# Altium schematic sheet MLDR187B_2.SchDoc
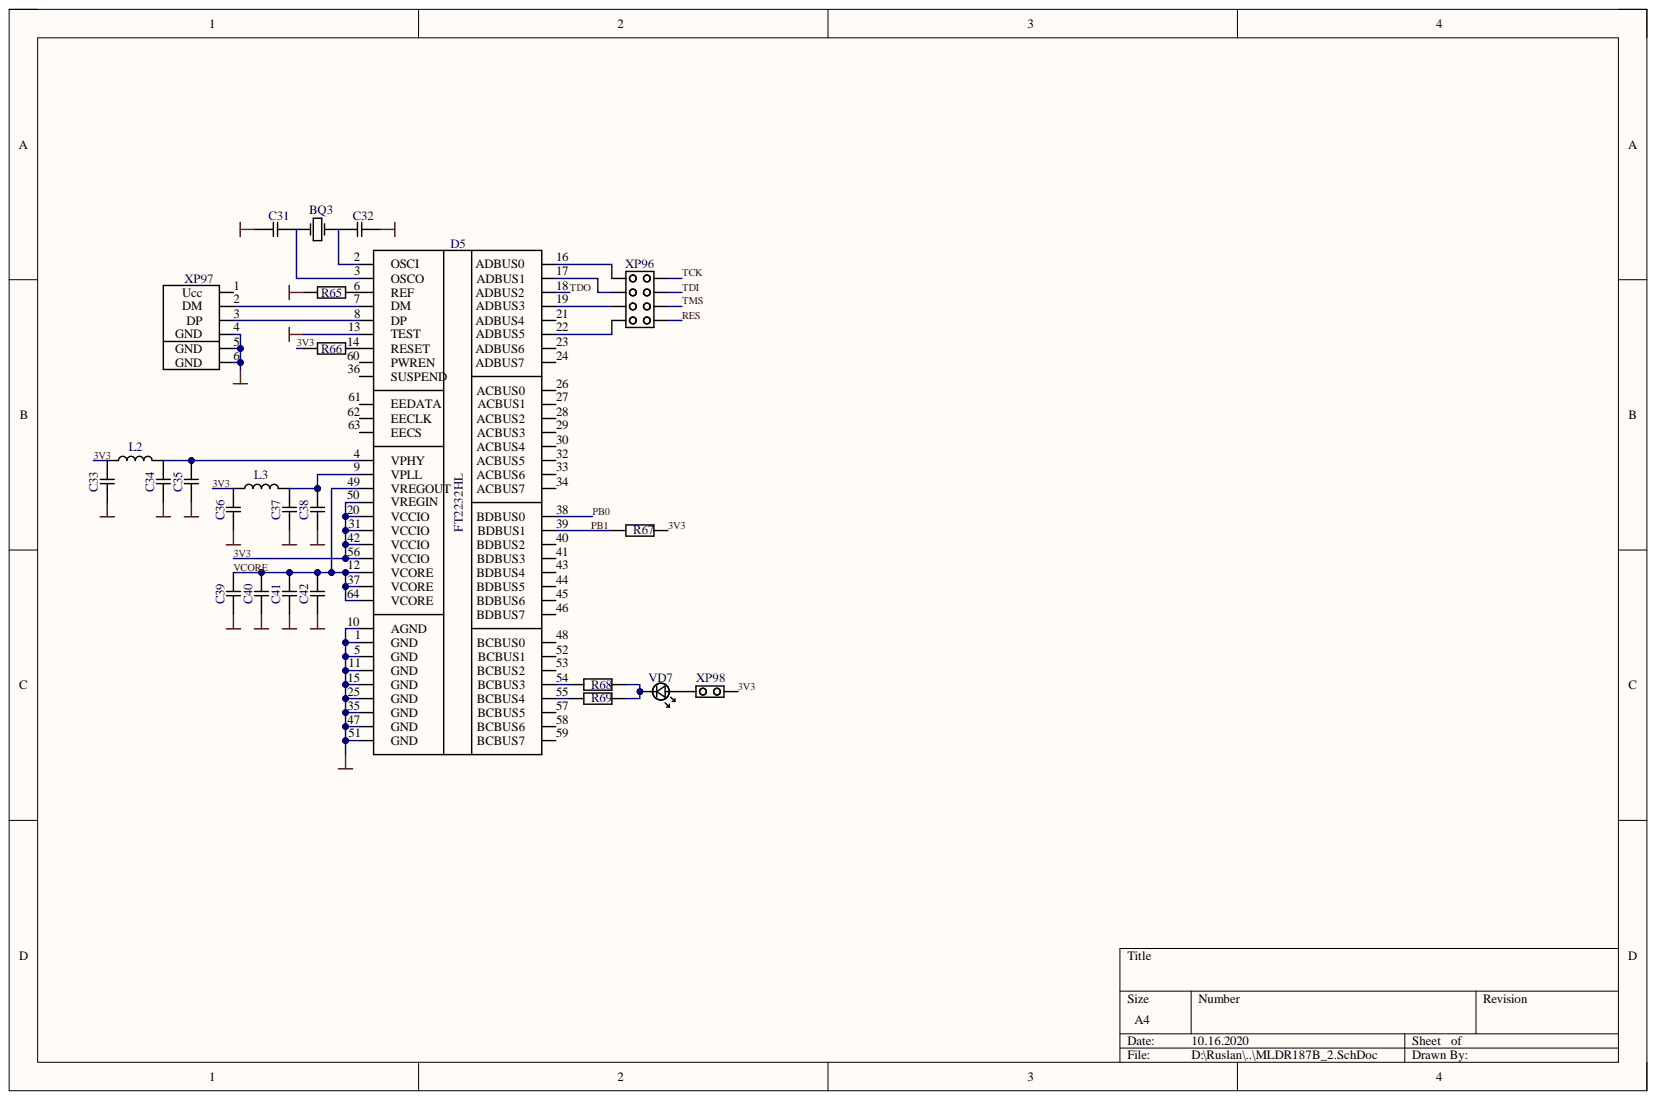
[diagram p0: MLDR187B_2.SchDoc]

## Parts
BQ3  KX-KT 12.0Mhz  [SMU-4]  (value not drawn)
C31  15pF 0603 NP0  [0603]  (value not drawn)
C32  15pF 0603 NP0  [0603]  (value not drawn)
C33  0.1uF 0603 X7R  [0603]  (value not drawn)
C34  0.1uF 0603 X7R  [0603]  (value not drawn)
C35  100pF 0603 NP0  [0603]  (value not drawn)
C36  0.1uF 0603 X7R  [0603]  (value not drawn)
C37  0.1uF 0603 X7R  [0603]  (value not drawn)
C38  100pF 0603 NP0  [0603]  (value not drawn)
C39  0.1uF 0603 X7R  [0603]  (value not drawn)
C40  0.1uF 0603 X7R  [0603]  (value not drawn)
C41  0.1uF 0603 X7R  [0603]  (value not drawn)
C42  0.1uF 0603 X7R  [0603]  (value not drawn)
D5  FT2232HL  [LQFP-64]
L2  BLM18HE102SN1D  [0603]  (value not drawn)
L3  BLM18HE102SN1D  [0603]  (value not drawn)
R65  12k 0603 1%  [0603]  (value not drawn)
R66  33k 0603 5%  [0603]  (value not drawn)
R67  33k 0603 5%  [0603]  (value not drawn)
R68  680Ohm 0603 5%  [0603]  (value not drawn)
R69  680Ohm 0603 5%  [0603]  (value not drawn)
VD7  LED 1206  [VD1206]  (value not drawn)
XP96  PLD-8  [PLD-8]  (value not drawn)
XP97  USBB  [USB_B]  (value not drawn)
XP98  PLS-2  [PLS-2]  (value not drawn)

## Nets
GND: C31.2 C32.1 C33.1 C34.1 C35.1 C36.1 C37.1 C38.1 C39.1 C40.1 C41.1 C42.1 D5.1(GND) D5.5(GND) D5.10(AGND) D5.11(GND) D5.13(TEST) D5.15(GND) D5.25(GND) D5.35(GND) D5.47(GND) D5.51(GND) R65.1 XP97.4(GND) XP97.5(GND) XP97.6(GND)
3V3: C33.2 C36.2 D5.20(VCCIO) D5.31(VCCIO) D5.42(VCCIO) D5.50(VREGIN) D5.56(VCCIO) L2.1 L3.1 R66.1 R67.1 XP98.2
PB0: D5.38(BDBUS0)
PB1: D5.39(BDBUS1) R67.2
RES: XP96.8
TCK: XP96.2
TDI: XP96.4
TDO: D5.18(ADBUS2)
TMS: XP96.6
VCORE: C39.2 C40.2 C41.2 C42.2 D5.12(VCORE) D5.37(VCORE) D5.49(VREGOUT) D5.64(VCORE)
NetBQ3_1: BQ3.1 C31.1 D5.3(OSCO)
NetBQ3_2: BQ3.2 C32.2 D5.2(OSCI)
NetC34_2: C34.2 C35.2 D5.4(VPHY) L2.2
NetC37_2: C37.2 C38.2 D5.9(VPLL) L3.2
NetD5_6: D5.6(REF) R65.2
NetD5_7: D5.7(DM) XP97.2(DM)
NetD5_8: D5.8(DP) XP97.3(DP)
NetD5_14: D5.14(RESET) R66.2
NetD5_16: D5.16(ADBUS0) XP96.1
NetD5_17: D5.17(ADBUS1) XP96.3
NetD5_19: D5.19(ADBUS3) XP96.5
NetD5_22: D5.22(ADBUS5) XP96.7
NetD5_54: D5.54(BCBUS3) R68.2
NetD5_55: D5.55(BCBUS4) R69.2
NetR68_1: R68.1 R69.1 VD7.2
NetVD7_1: VD7.1 XP98.1

Not connected: D5 21(ADBUS4) 23(ADBUS6) 24(ADBUS7) 26(ACBUS0) 27(ACBUS1) 28(ACBUS2) 29(ACBUS3) 30(ACBUS4) 32(ACBUS5) 33(ACBUS6) 34(ACBUS7) 36(SUSPEND) 40(BDBUS2) 41(BDBUS3) 43(BDBUS4) 44(BDBUS5) 45(BDBUS6) 46(BDBUS7) 48(BCBUS0) 52(BCBUS1) 53(BCBUS2) 57(BCBUS5) 58(BCBUS6) 59(BCBUS7) 60(PWREN) 61(EEDATA) 62(EECLK) 63(EECS); XP97 1(Ucc)

## Library data of the parts
BQ3 = HC-49 SM: Component Kind: Standard; Library Name: PassiveComponents.IntLib
C31-C42 = C_0603: 0603; Component Kind: Standard; Library Name: PassiveComponents.IntLib
L2 L3 = L_0603: 0603; Component Kind: Standard; Library Name: PassiveComponents.IntLib
R65-R69 = R_0603: 0603; Component Kind: Standard; Library Name: PassiveComponents.IntLib
VD7 = LED_1206: 1206; Component Kind: Standard; Library Name: ActiveComponents.IntLib
D5 = FT2232HL: Component Kind: Standard; Library Name: OtherMC.IntLib
XP96 = PLD-8: Component Kind: Standard; Description: PLD-8; Library Name: ConnectorsMk2.IntLib
XP97 = USBB: Component Kind: Standard; Library Name: ConnectorsMk2.IntLib
XP98 = PLS-2: Component Kind: Standard; Description: PLS-2; Library Name: ConnectorsMk2.IntLib
